# Altium schematic sheet Schematic Prints-Variant("All Documents",Physical)
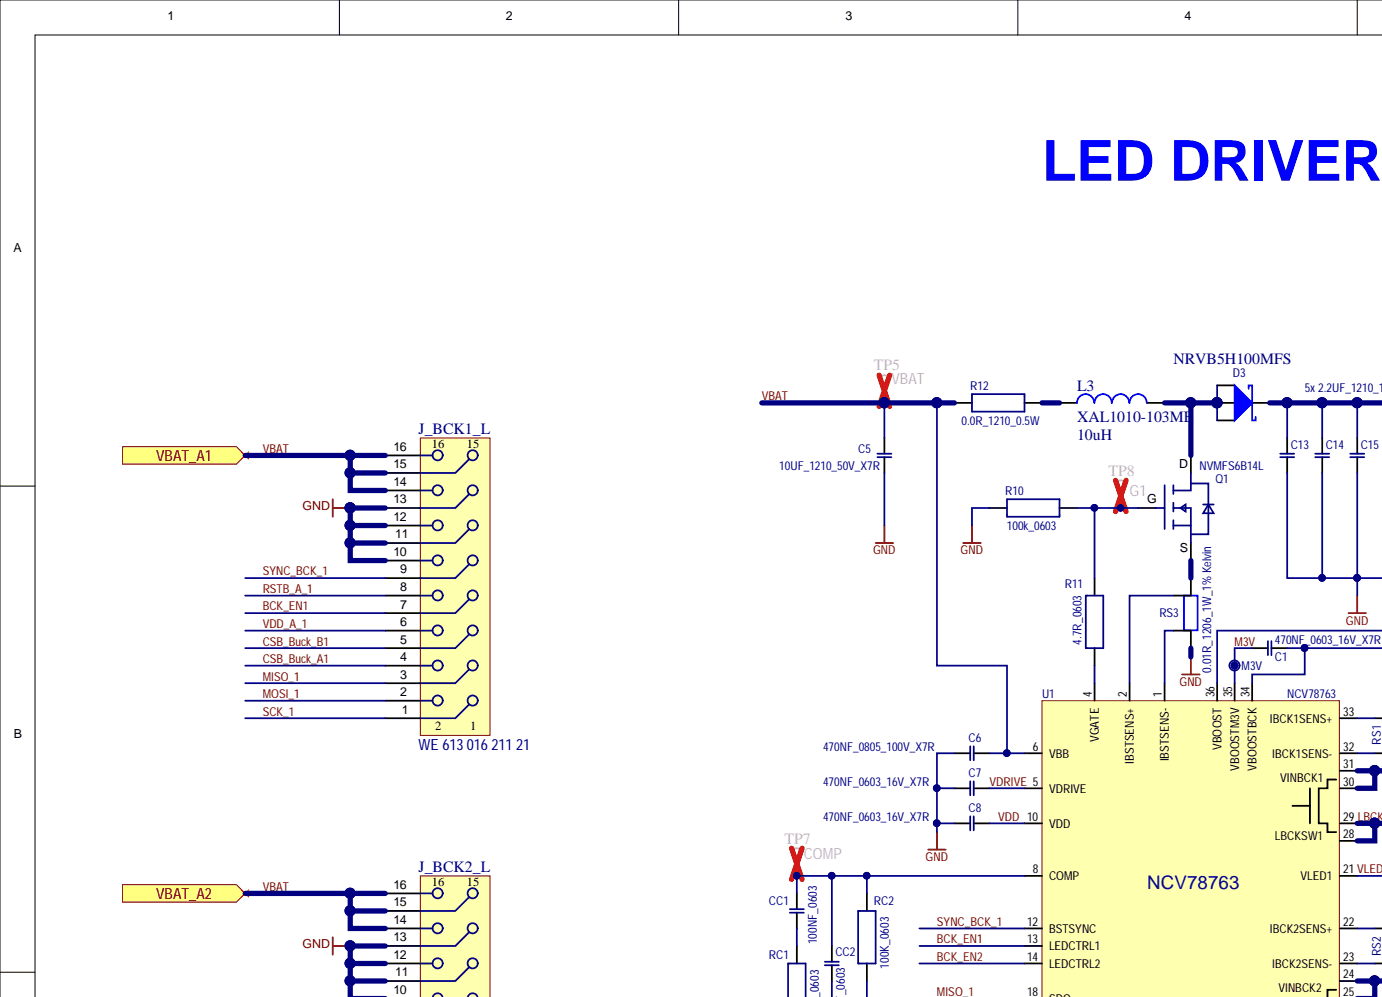
[diagram p0: Schematic Prints-Variant("All Documents",Physical), top left]
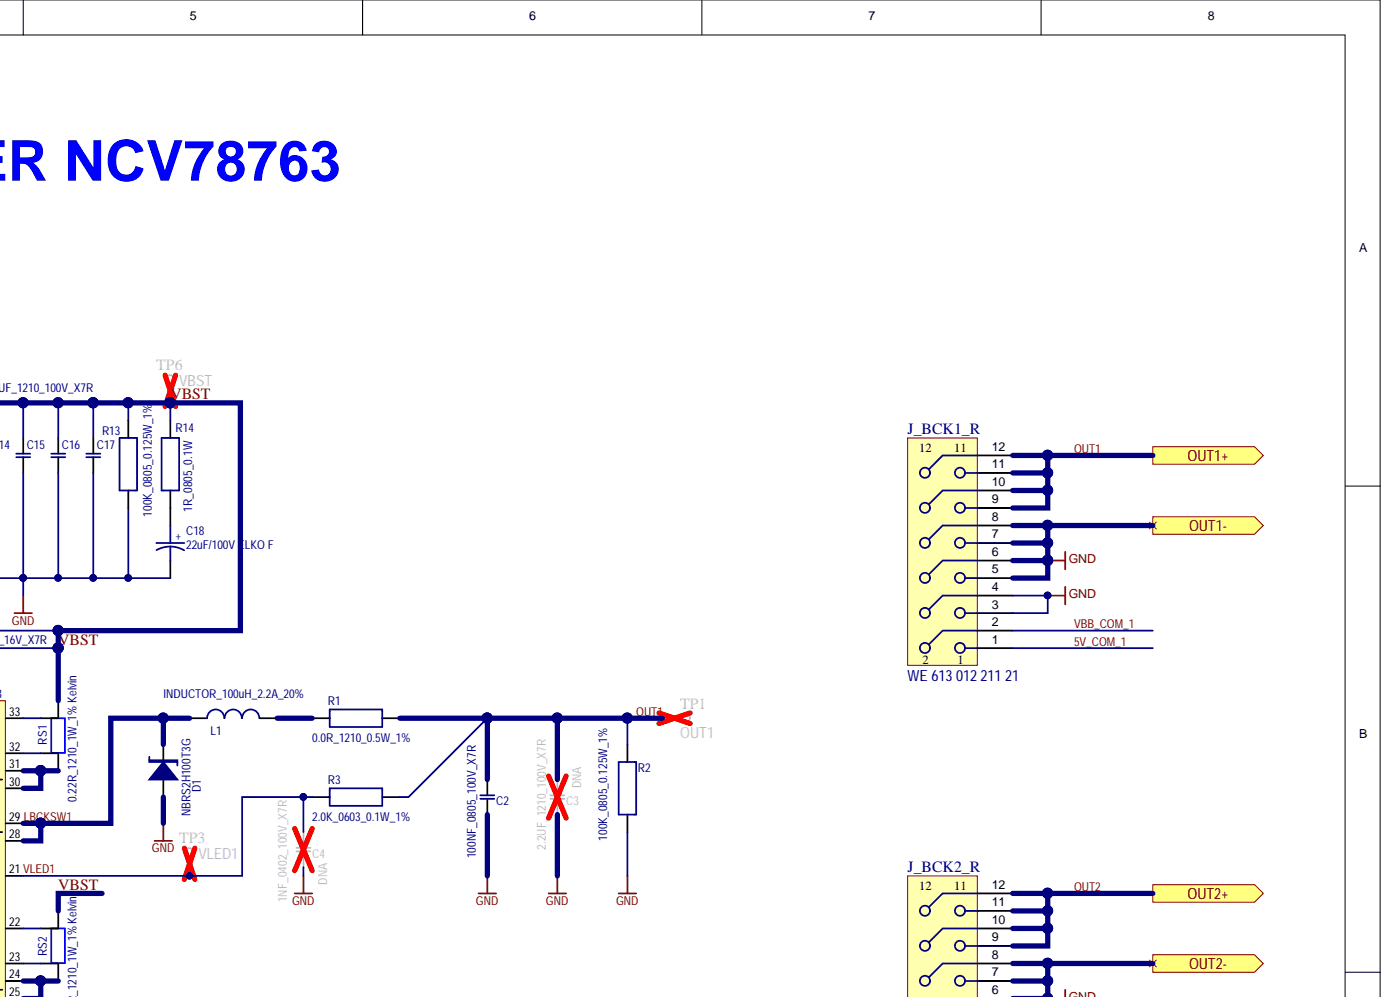
[diagram p1: Schematic Prints-Variant("All Documents",Physical), top right]
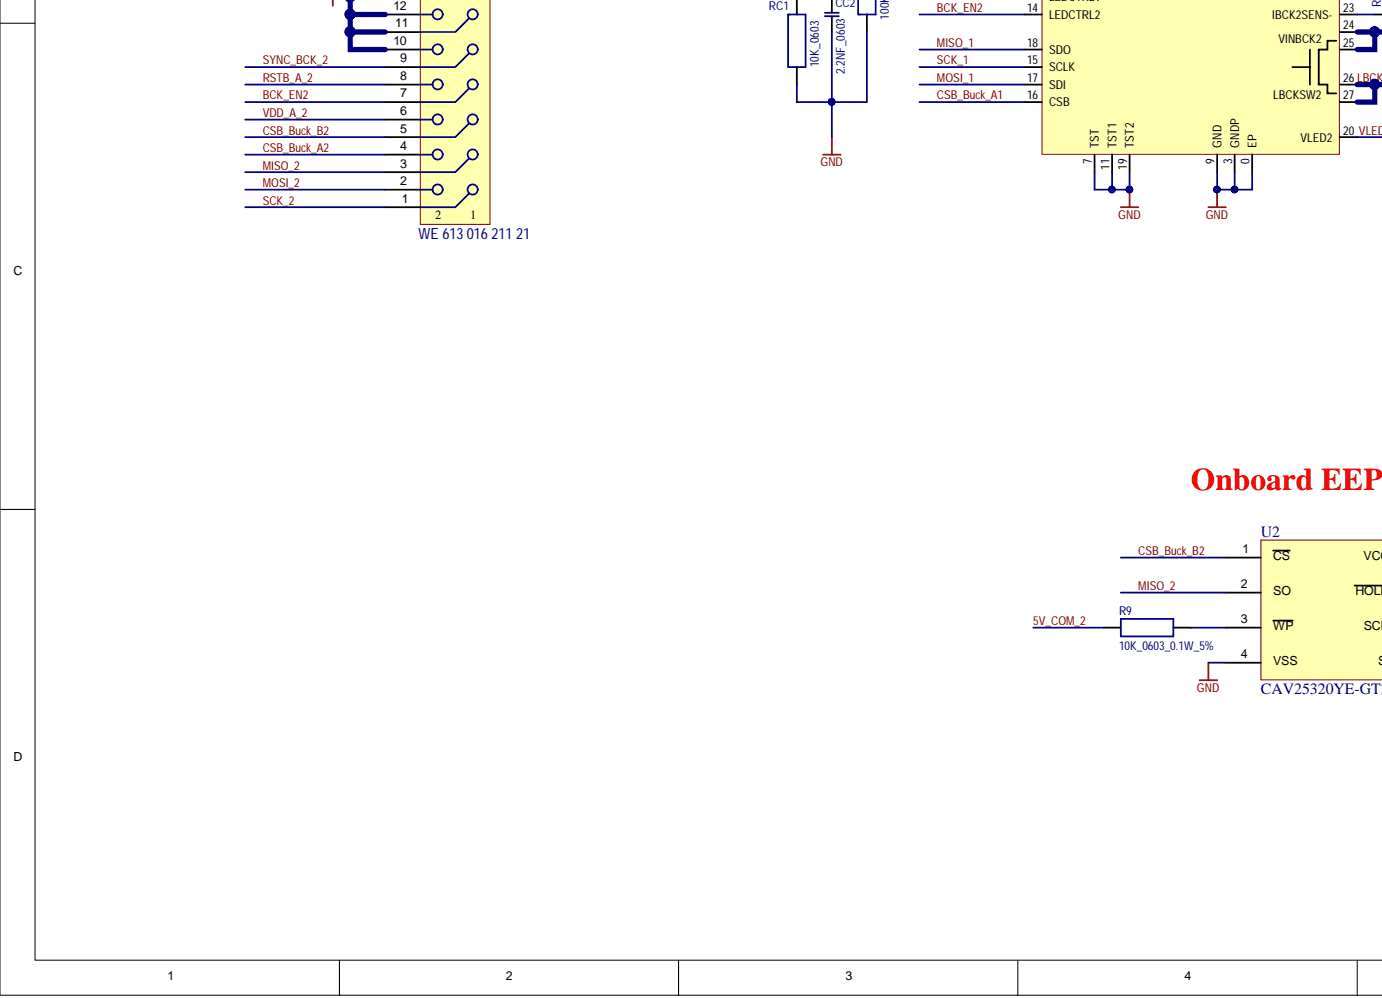
[diagram p2: Schematic Prints-Variant("All Documents",Physical), bottom left]
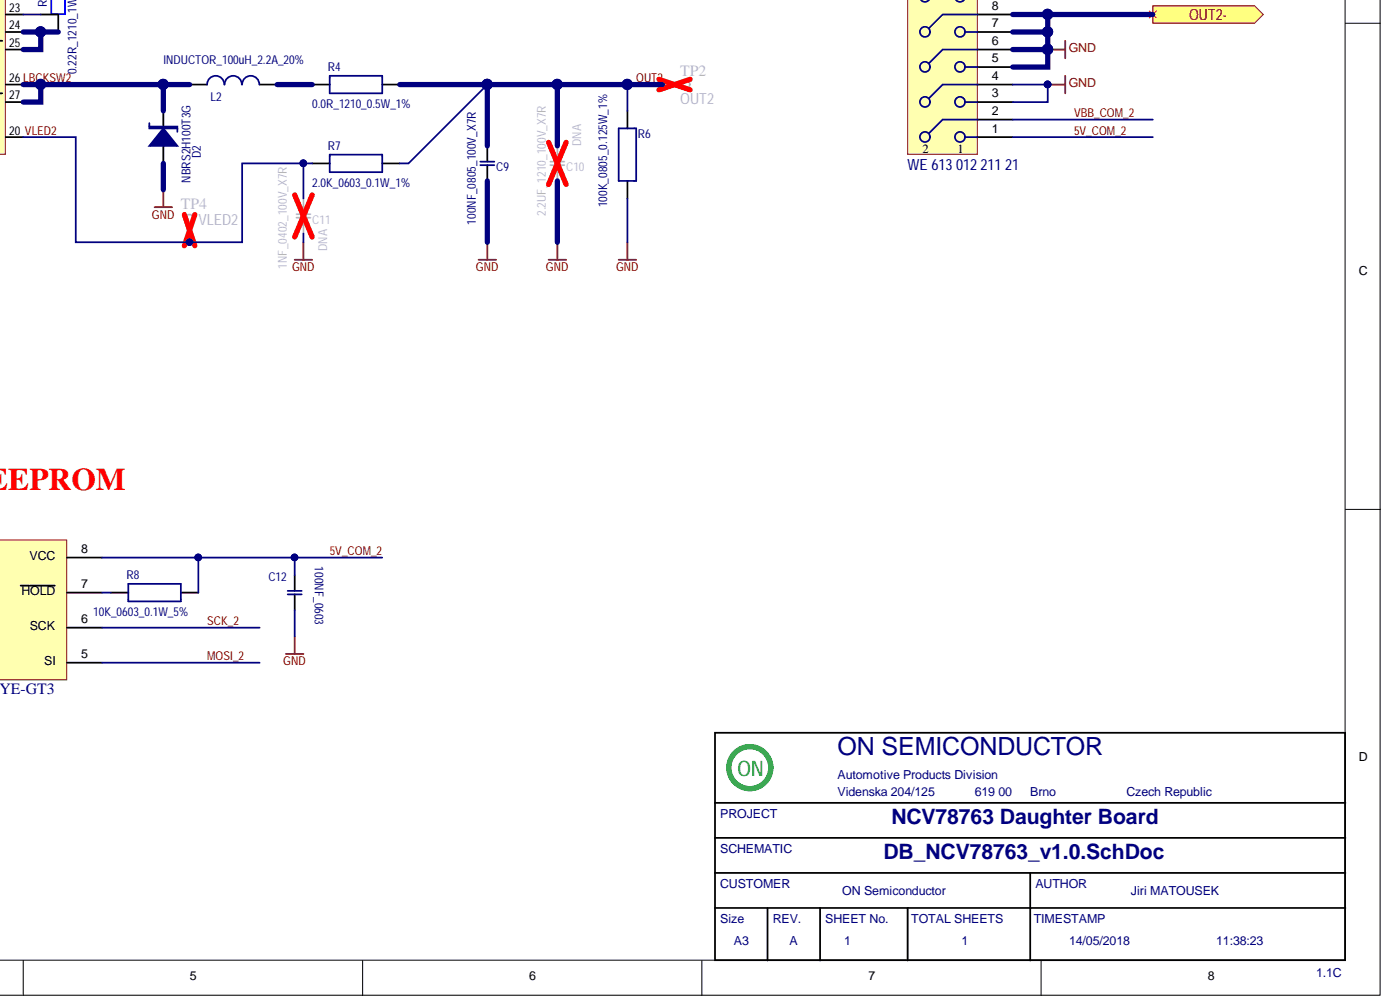
[diagram p3: Schematic Prints-Variant("All Documents",Physical), bottom right]

## Parts
C1  470NF_0603_16V_X7R  [C0603_MW]
C2  100NF_0805_100V_X7R  [CAP0805]
C3  2.2UF_1210_100V_X7R  Not Assembly: DNA  [C1210]
C4  1NF_0402_100V_X7R  Not Assembly: DNA  [C1005(0402)]
C5  10UF_1210_50V_X7R  [CAP1210]
C6  470NF_0805_100V_X7R  [CAP0805]
C7  470NF_0603_16V_X7R  [C0603_MW]
C8  470NF_0603_16V_X7R  [C0603_MW]
C9  100NF_0805_100V_X7R  [CAP0805]
C10  2.2UF_1210_100V_X7R  Not Assembly: DNA  [C1210]
C11  1NF_0402_100V_X7R  Not Assembly: DNA  [C1005(0402)]
C12  100NF_0603  [CAP0603_M1]
C13  2.2UF_1210_100V_X7R  [C1210]
C14  2.2UF_1210_100V_X7R  [C1210]
C15  2.2UF_1210_100V_X7R  [C1210]
C16  2.2UF_1210_100V_X7R  [C1210]
C17  2.2UF_1210_100V_X7R  [C1210]
C18  22uF/100V ELKO F  [PANASONIC_FC_F]
CC1  100NF_0603  [CAP0603_M1]
CC2  2.2NF_0603  [CAP0603]
D1  NBRS2H100T3G  [SMB]
D2  NBRS2H100T3G  [SMB]
D3  NRVB5H100MFS  [DFN5_615X515P127EP_CASE-488AA_SSSGD]
J_BCK1_L  WE 613 016 211 21  [WE 613 016 211 21]
J_BCK1_R  WE 613 012 211 21  [WE 613 012 211 21]
J_BCK2_L  WE 613 016 211 21  [WE 613 016 211 21]
J_BCK2_R  WE 613 012 211 21  [WE 613 012 211 21]
L1  INDUCTOR_100uH_2.2A_20%  [MCSDRH125B]
L2  INDUCTOR_100uH_2.2A_20%  [MCSDRH125B]
L3  XAL1010-103ME  value 10uH  [Inductor - booster]
M3V  TPAD  [PAD_1.0MM]  (value not drawn)  (no BOM)
Q1  NVMFS6B14L  [DFN5_615X515P127EP_CASE-488AA_SSSGD]
R1  0.0R_1210_0.5W_1%  [R1210_WIRE]
R2  100K_0805_0.125W_1%  [R0805]
R3  2.0K_0603_0.1W_1%  [R0603_MW]
R4  0.0R_1210_0.5W_1%  [R1210_WIRE]
R6  100K_0805_0.125W_1%  [R0805]
R7  2.0K_0603_0.1W_1%  [R0603_MW]
R8  10K_0603_0.1W_5%  [R0603]
R9  10K_0603_0.1W_5%  [R0603]
R10  100k_0603  [R0603]
R11  4.7R_0603  [R0603]
R12  0.0R_1210_0.5W  [1210]
R13  100K_0805_0.125W_1%  [R0805]
R14  1R_0805_0.1W  [R0805]
RC1  10K_0603  [R0603]
RC2  100K_0603  [R0603]
RS1  0.22R_1210_1W_1% Kelvin  [R1210 kelvin]  (net tie)
RS2  0.22R_1210_1W_1% Kelvin  [R1210 kelvin]  (net tie)
RS3  0.01R_1206_1W_1% Kelvin  [R1206 kelvin]  (net tie)
TP1  OUT1  [TP_1mm]
TP2  OUT2  [TP_1mm]
TP3  VLED1  [TP_1mm]
TP4  VLED2  [TP_1mm]
TP5  VBAT  [TP_1mm]
TP6  VBST  [TP_1mm]
TP7  COMP  [TP_1mm]
TP8  G1  [TP_1mm]
U1  NCV78763  [POWER_SSOP36_EP]
U2  CAV25320YE-GT3  [TSSOP8_4.4x3]

## Nets
GND: C2.2 C3.1 C4.1 C5.2 C6.1 C7.2 C8.2 C9.2 C10.1 C11.1 C12.2 C13.1 C14.1 C15.1 C16.1 C17.1 C18.2 CC2.2 D1.A D2.A J_BCK1_L.10 J_BCK1_L.11 J_BCK1_L.12 J_BCK1_L.13 J_BCK1_R.3 J_BCK1_R.4 J_BCK1_R.5 J_BCK1_R.6 J_BCK1_R.7 J_BCK1_R.8 J_BCK2_L.10 J_BCK2_L.11 J_BCK2_L.12 J_BCK2_L.13 J_BCK2_R.3 J_BCK2_R.4 J_BCK2_R.5 J_BCK2_R.6 J_BCK2_R.7 J_BCK2_R.8 R2.2 R6.2 R10.2 R13.2 RC1.1 RC2.1 RS3.2 U1.0(EP) U1.3(GNDP) U1.7(TST) U1.9(GND) U1.11(TST1) U1.19(TST2) U2.4(VSS)
5V_COM_1: J_BCK1_R.1
5V_COM_2: C12.1 J_BCK2_R.1 R8.1 R9.2 U2.8(VCC)
BCK_EN1: J_BCK1_L.7 U1.13(LEDCTRL1)
BCK_EN2: J_BCK2_L.7 U1.14(LEDCTRL2)
CSB_Buck_A1: J_BCK1_L.4 U1.16(CSB)
CSB_Buck_A2: J_BCK2_L.4
CSB_Buck_B1: J_BCK1_L.5
CSB_Buck_B2: J_BCK2_L.5 U2.1(CS)
LBCKSW1: D1.K L1.1 U1.28(LBCKSW1) U1.29
LBCKSW2: D2.K L2.1 U1.26 U1.27(LBCKSW2)
M3V: C1.1 M3V.1 U1.35(VBOOSTM3V)
MISO_1: J_BCK1_L.3 U1.18(SDO)
MISO_2: J_BCK2_L.3 U2.2(SO)
MOSI_1: J_BCK1_L.2 U1.17(SDI)
MOSI_2: J_BCK2_L.2 U2.5(SI)
OUT1: C2.1 C3.2 J_BCK1_R.9 J_BCK1_R.10 J_BCK1_R.11 J_BCK1_R.12 R1.2 R2.1 R3.2 TP1.1
OUT2: C9.1 C10.2 J_BCK2_R.9 J_BCK2_R.10 J_BCK2_R.11 J_BCK2_R.12 R4.2 R6.1 R7.2 TP2.1
RSTB_A_1: J_BCK1_L.8
RSTB_A_2: J_BCK2_L.8
SCK_1: J_BCK1_L.1 U1.15(SCLK)
SCK_2: J_BCK2_L.1 U2.6(SCK)
SYNC_BCK_1: J_BCK1_L.9 U1.12(BSTSYNC)
SYNC_BCK_2: J_BCK2_L.9
VBAT: C5.1 C6.2 J_BCK1_L.14 J_BCK1_L.15 J_BCK1_L.16 J_BCK2_L.14 J_BCK2_L.15 J_BCK2_L.16 R12.1 TP5.1 U1.6(VBB)
VBB_COM_1: J_BCK1_R.2
VBB_COM_2: J_BCK2_R.2
VBST: C1.2 C13.2 C14.2 C15.2 C16.2 C17.2 D3.D R13.1 R14.2 RS1.1 RS2.1 TP6.1 U1.34(VBOOSTBCK) U1.36(VBOOST)
VDD: C8.1 U1.10(VDD)
VDD_A_1: J_BCK1_L.6
VDD_A_2: J_BCK2_L.6
VDRIVE: C7.1 U1.5(VDRIVE)
VLED1: C4.2 R3.1 TP3.1 U1.21(VLED1)
VLED2: C11.2 R7.1 TP4.1 U1.20(VLED2)
NetC18_1: C18.1 R14.1
NetCC1_1: CC1.1 RC1.2
NetCC1_2: CC1.2 CC2.1 RC2.2 TP7.1 U1.8(COMP)
NetD3_S: D3.S L3.2 Q1.D
NetL1_2: L1.2 R1.1
NetL2_2: L2.2 R4.1
NetL3_1: L3.1 R12.2
NetQ1_G: Q1.G R10.1 R11.2 TP8.1
NetQ1_S: Q1.S RS3.1
NetR8_2: R8.2 U2.7(HOLD)
NetR9_1: R9.1 U2.3(WP)
NetR11_1: R11.1 U1.4(VGATE)
NetRS1_2: RS1.2 U1.30 U1.31
NetRS1_3: RS1.3 U1.33(IBCK1SENS+)
NetRS1_4: RS1.4 U1.32(IBCK1SENS-)
NetRS2_2: RS2.2 U1.24 U1.25
NetRS2_3: RS2.3 U1.22(IBCK2SENS+)
NetRS2_4: RS2.4 U1.23(IBCK2SENS-)
NetRS3_3: RS3.3 U1.2(IBSTSENS+)
NetRS3_4: RS3.4 U1.1(IBSTSENS-)

## Library data of the parts
C1 C7 C8 = 470NF_0603_16V_X7R: 1907282  Capacitor X7R_0603_470NF_10%_16V; C1608X7R1C474K080AC;  TDK; RoHS=Yes; Supplier Part Number 1: 1907282; Supplier 1: Farnell; Simulation: CAP; Library Name: Schlib1.SchLib; Component Kind: Standard
C2 C9 = 100NF_0805_100V_X7R: 130-1805    Capacitor MLC-X7R_0805_100nF_10%_100V; 08051C104KAZ2A; AVX; RoHS=Yes; Simulation: CAP; Library Name: CAP0805_X7R.SchLib; Component Kind: Standard
C3 C10 C13-C17 = 2.2UF_1210_100V_X7R: 1793832  X7R_1210_2.2uF_10%_100V; C1210C225K1RACTU; KEMET; RoHS=Yes; Supplier Part Number 1: 1793832; Supplier 1: Farnell; Simulation: CAP; Library Name: L825_EMC_V1.SCHLIB; Component Kind: Standard
C4 C11 = 1NF_0402_100V_X7R: 247-0417    Capacitor MLC_0402_1NF_10%_100V; GCM155R72A102KA37D; MURATA; AEC-Q200; RoHS=Yes; Supplier Part Number 1: 2470417; Supplier 1: Farnell; Simulation: CAP; Library Name: DB_NCV78825_v1.2.SchLib; Component Kind: Standard
C5 = 10UF_1210_50V_X7R: 2449143  X7R_1210_10uF_10%_50V; C1210C106K5RACTU; KEMET; RoHS=Yes; Supplier Part Number 1: 2449143; Supplier 1: Farnell; Simulation: CAP; Library Name: Schlib1.SchLib; Component Kind: Standard
C6 = 100NF_0805_100V_X7R: 1867963  Capacitor X7R_0805_470nF_10%_100V; AVX; RoHS=Yes; Simulation: CAP; Library Name: CAP0805_X7R.SchLib; Component Kind: Standard
C12 CC1 = 100NF_0603_50V_X7R: 2521996  Capacitor X7R_0603_100NF_10%_25V; TDK; RoHS=Yes; Value: 100nF; Signature_Author: Zagata; Supplier Part Number 1: 1288255; Supplier 1: Farnell; Simulation: CAP; Library Name: CAP0603_X7R.SchLib; Component Kind: Standard; Category: Capacitors; Signature_Date_LastModified: 2013-08-27; Signature_Date_Created: 2013-08-27
C18 = 22uF/100V ELKO F: 2079242  Capacitor 22uF/100V; EEETG2A220UP; PANASONIC; RoHS=Yes; Supplier Part Number 1: 2079242; Supplier 1: Farnell; Simulation: CAP; Library Name: DB_NCV78763_v1.0.SchLib; Component Kind: Standard
CC2 = 2.2NF_0603_50V_X7R: 2672140  Capacitor C0G 0603_2.2NF_10%_50V; RoHS=Yes; Supplier Part Number 1: 498592; Supplier 1: Farnell; Simulation: CAP; Library Name: CAP0603_X7R.SchLib; Component Kind: Standard
D1 D2 = MBRS2H100T3G: ONSEMI      Diode 2A/100V Schottky Power Rectifier; MBRS2H100T3G; ON; Not Assembly: DNA; Library Name: DIODES_SCHOTTKY.SchLib; Component Kind: Standard
D3 = NRVB10100MFS: ONSEMI  NRVB5H100MFS  Schottky Diode; Value: MBR5100MFS; Published: 8-Jun-2000; PackageReference: SMB; PackageDescription: DO-214-AA/SMB; 2 C-Bend Leads; Body 5.3 x 3.6 mm, inc. leads (LxW); Library Name: Schlib1.SchLib; LatestRevisionNote: Re-released for DXP Platform.; LatestRevisionDate: 17-Jul-2002; Component Kind: Standard; Code_JEDEC: DO-214-AA; Publisher: Altium Limited
J_BCK1_L J_BCK2_L = WE 613 016 211 21: 235-6136      STRAIGHT HEADER, 2x8-Pin, Pitch 2.54mm, Dual row,  Board-To-Board WURTH 61301621121, WR-PHD Series, Through hole; Signal Integrity: Connector; Library Name: DB_NCV78825_v1.2.SchLib; LatestRevisionNote: Re-released for DXP Platform.; LatestRevisionDate: 17-Jul-2002; Component Kind: Standard; Publisher: Altium Limited
J_BCK1_R J_BCK2_R = WE 613 012 211 21: 235-6134      STRAIGHT HEADER, 2x6-Pin, Pitch 2.54mm, Dual row,  Board-To-Board WURTH 61301221121, WR-PHD Series, Through hole; Signal Integrity: Connector; Library Name: DB_NCV78825_v1.2.SchLib; LatestRevisionNote: Re-released for DXP Platform.; LatestRevisionDate: 17-Jul-2002; Component Kind: Standard; Publisher: Altium Limited
L1 L2 = INDUKTOR_47uH_3.2A_20%: 2288545  SMD INDUCTOR 100uH/2.2A/20%/SHIELD; MSS1278T-104MLD; COILCRAFT; RoHS=1; Supplier Part Number 1: 2288512; Supplier 1: Farnell; Library Name: L825_PB_SSOP36EP_V1.SCHLIB; Component Kind: Standard
L3 = Inductor: 2289021  SMD INDUCTOR 10uH/15.5A/20%/SHIELD; XAL1010-103MED; COILCRAFT; RoHS=1; Simulation: INDUCTOR; Signal Integrity: Inductor; Published: 8-Jun-2000; PackageDescription: Chip Inductor; Library Name: DB_NCV78763_v1.0.SchLib; LatestRevisionDate: 29-May-2009; Component Kind: Standard; Publisher: Altium Limited
M3V = TPAD: xxx-xxxx    TEST PAD - NO COMPONENT TO SOLDER; Signature_Author: Zagata; Library Name: Schlib1.SchLib; Category: Misc; Signature_Date_LastModified: 2013-08-27; Signature_Date_Created: 2013-08-27
Q1 = NVMFS6B14L: ONSEMI  NVMFS6B14L  MOSFET Tranzistor, N Chanell, 55 A, 100 V, 0.0105 ohm, 10 V, 3 V ; ONSEMI; Signature_Author: Konvalinka; Library Name: Schlib1.SchLib; Component Kind: Standard; Category: Transistors
R1 R4 R12 = 0.0R_1210_0.25W_1%: 1653183  RESISTOR 1210_0R0_0.5W_1%_200V; Vishay; RoHS=Yes; Supplier Part Number 1: 2324002; Supplier 1: Farnell; Simulation: RESISTOR; Library Name: Schlib1.SchLib; Component Kind: Standard
R2 R6 R13 = 100K_0805_0.1W_1%: 1469860  RESISTOR 0805_100K_0.125W_1%_100V; Vishay; RoHS=Yes; Simulation: RESISTOR; Library Name: Resistor0805.SchLib; Component Kind: Standard
R3 R7 = 2.0K_0603_0.063W_1%: 1469764  RESISTOR 0603_2.0K_0.1W_1%_50V;  Vishay; RoHS=Yes; Supplier Part Number 1: 9330763; Supplier 1: Farnell; Simulation: RESISTOR; Library Name: Resistor0603_0.063W.SchLib; Component Kind: Standard
R8 R9 RC1 = 10K_0603_0.1W_5%: 9233504  RESISTOR 0603_10K_0.1W_5%_50V; PHYCOMP; RoHS=Yes; Supplier Part Number 1: 9233504; Supplier 1: Farnell; Simulation: RESISTOR; Library Name: Resistor0603_0.063W.SchLib; Component Kind: Standard
R10 = 4.7R_0603_0.063W_1%: 9233628  RESISTOR 0603_100K_0.1W_5%_50V; PHYCOMP; RoHS=Yes; Value: 3.3k; Supplier Part Number 1: 9331280; Supplier 1: Farnell; Simulation: RESISTOR; Library Name: Resistor0603_0.063W.SchLib; Component Kind: Standard
R11 = 4.7R_0603_0.063W_1%: 2447390  RESISTOR 0603_4.7R_0.1W_1%_50V; MC; RoHS=Yes; Value: 3.3k; Supplier Part Number 1: 9331280; Supplier 1: Farnell; Simulation: RESISTOR; Library Name: Resistor0603_0.063W.SchLib; Component Kind: Standard
R14 = 1.0R_0805_0.1W_1%: 1506147  RESISTOR 0805_1R0_0.25W_1%_200V, Welwyn; RoHS=Yes; Simulation: RESISTOR; Library Name: Resistor0805.SchLib; Component Kind: Standard
RC2 = 100K_0603_0.063W_5%: 9233628  RESISTOR 0603_100K_0.1W_5%_50V; PHYCOMP; RoHS=Yes; Simulation: RESISTOR; Library Name: Resistor0603_0.063W.SchLib; Component Kind: Standard
RS1 RS2 = 0.22R_1210_1W_1% Kelvin: 1838674  RESISTOR 1210_0R22_1W_1%; R1210; RoHS=Yes; Value: 220m; Supplier Part Number 1: 1838674; Supplier 1: Farnell; Published: 8-Jun-2000; Library Name: DB_NCV78763_v1.0.SchLib; LatestRevisionNote: Re-released for DXP Platform.; LatestRevisionDate: 17-Jul-2002; Publisher: Altium Limited
RS3 = 0.010R_1206_1W_1% Kelvin: 2145283  RESISTOR 1206_0R010_1W_1%; R1206; KOA; RoHS=Yes; Value: 10m; Supplier Part Number 1: 2145283; Supplier 1: Farnell; Published: 8-Jun-2000; PackageReference: AXIAL-0.4; PackageDescription: Axial Device, Thru-Hole; 2 Leads; 0.4 in Pin Spacing; Library Name: Schlib1.SchLib; LatestRevisionNote: Re-released for DXP Platform.; LatestRevisionDate: 17-Jul-2002; Publisher: Altium Limited
TP1-TP8 = TestPoint: 873-1128    (PK100) TESTPIN 200 SER. HOLE 1.0 BLACK; 20-2137; VERO; RoHS=Yes (repl. for 240-333); Component Kind: Standard; Library Name: ONAutomotive.IntLib
U1 = ONSEMI_NCV78763: ONSEMI NCV78763, LED Driver, 1x Booster + 2x 1.6A Buck channels; SSOP36-EP; RoHS=Yes; Signature_Author: Zagata; Status: CREATED; Library Name: DB_NCV78763_v1.0.SchLib; Component Kind: Standard; Category: ICs; Signature_Date_LastModified: 2014-08-01; Signature_Date_Created: 2014-05-07
U2 = CAV25320: ONSEMI  CAV25320YE-GT3  EEPROM Serial-SPI 32K-Bit 4K X 8 3.3V/5V 8-Pin TSSOP T/R; RoHS=Yes; Library Name: Schlib1.SchLib; Component Kind: Standard
